# Altium schematic sheet Power System.SchDoc
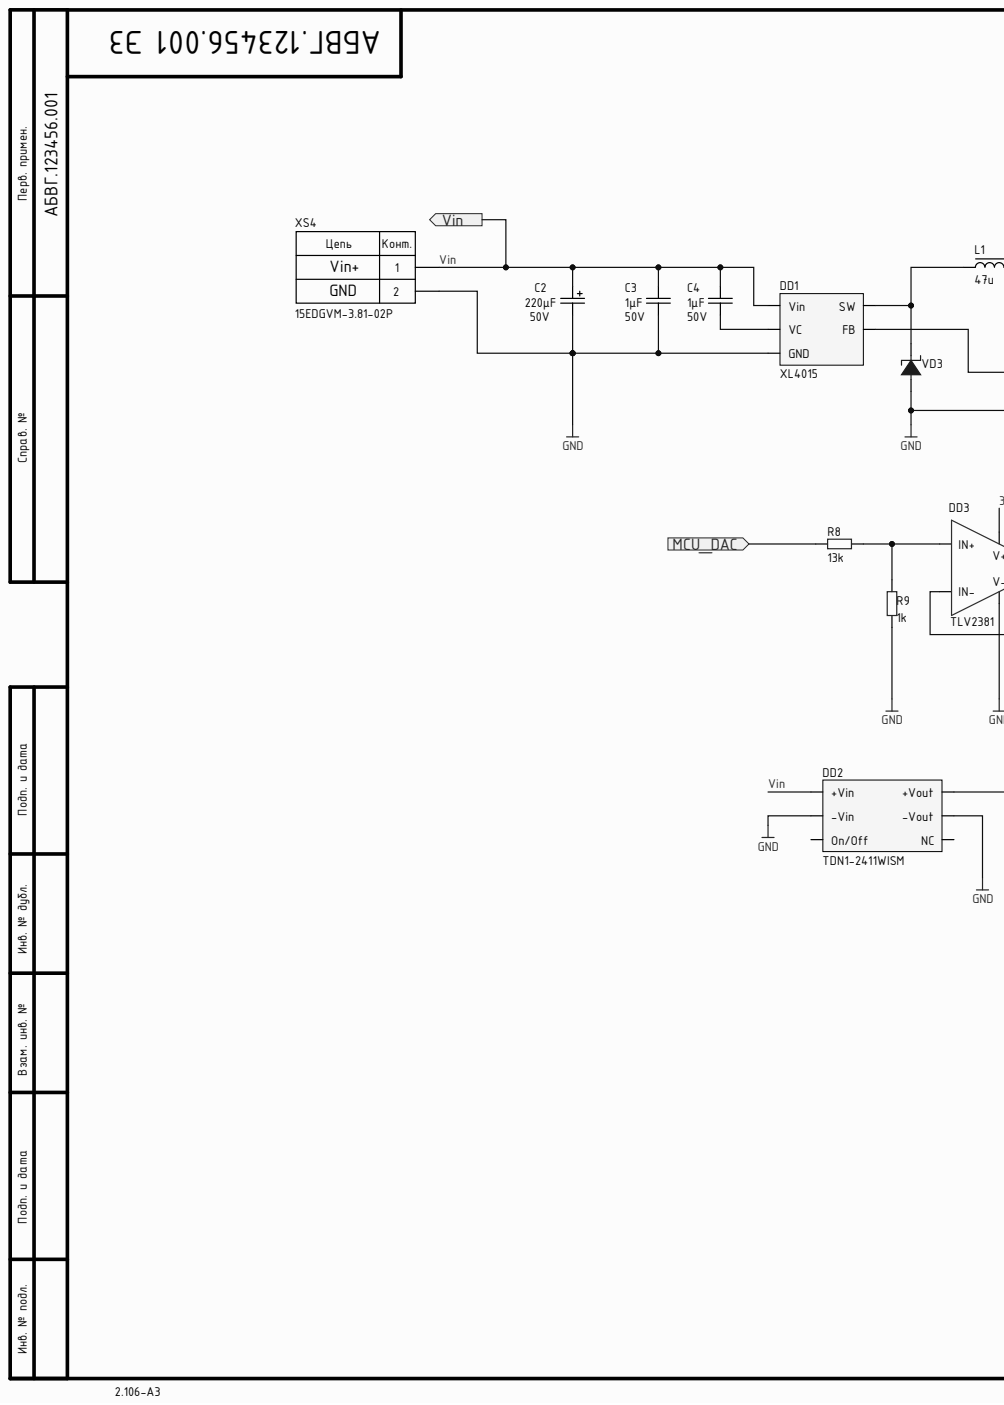
[diagram p0: Power System.SchDoc, left]
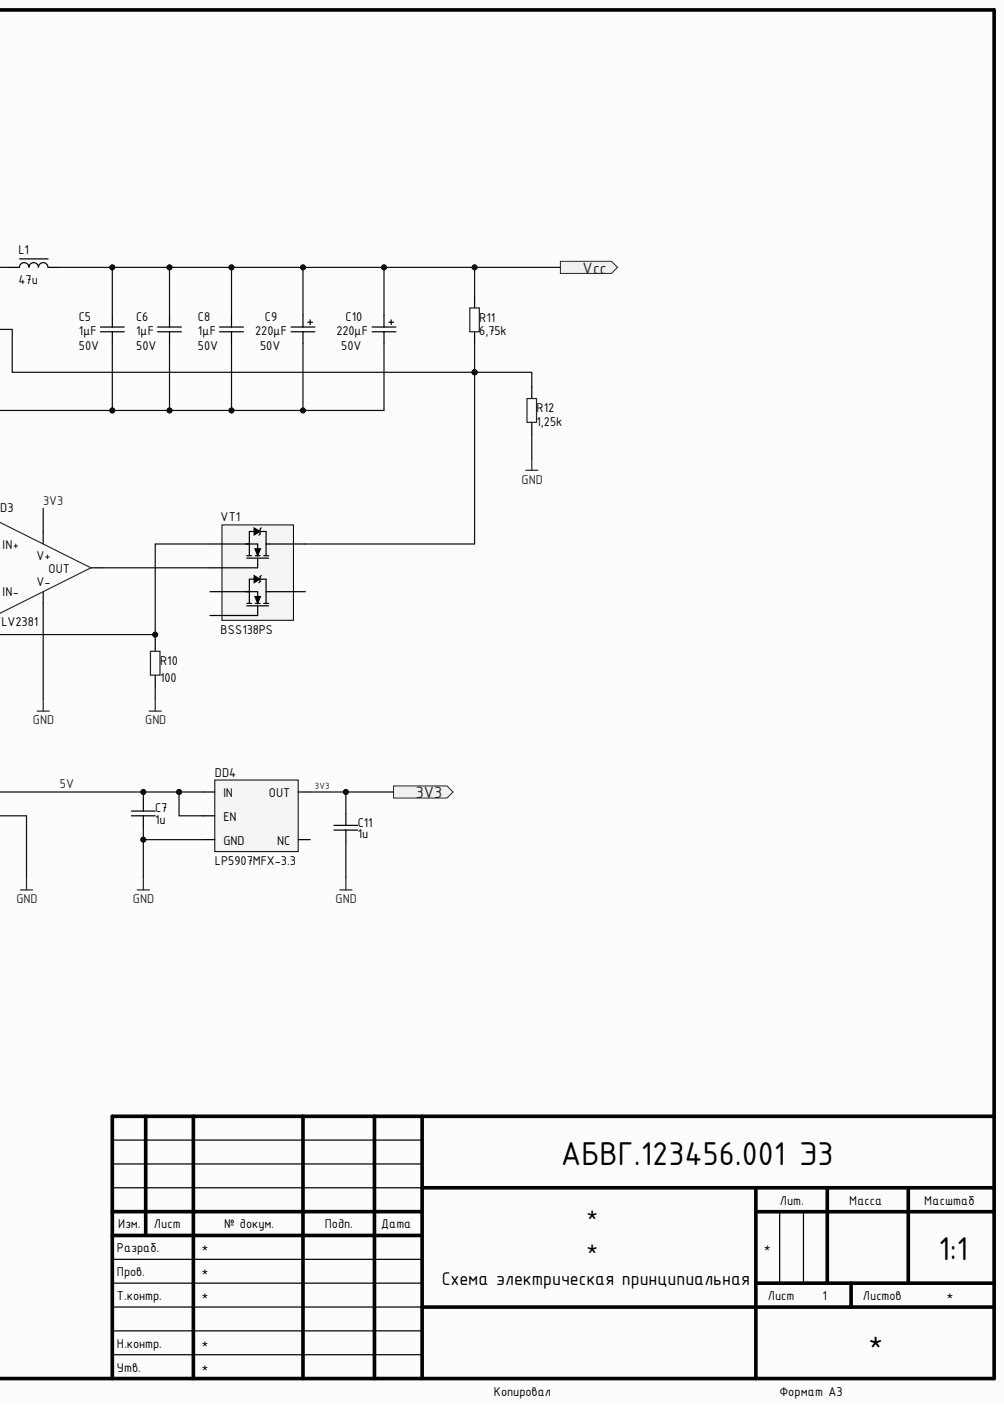
[diagram p1: Power System.SchDoc, right]

## Parts
C2  value 220μF  Voltage: 50V  [CAP: SMD-G Package(10x10)]
C3  value 1μF  Voltage: 50V  [CAP: 0805]  (hidden comment: Ceramic capacitor, 1μF, X7R, ±10%, 50V, 0805, -55...+125°C)
C4  value 1μF  Voltage: 50V  [CAP: 0805]  (hidden comment: Ceramic capacitor, 1μF, X7R, ±10%, 50V, 0805, -55...+125°C)
C5  value 1μF  Voltage: 50V  [CAP: 0805]  (hidden comment: Ceramic capacitor, 1μF, X7R, ±10%, 50V, 0805, -55...+125°C)
C6  value 1μF  Voltage: 50V  [CAP: 0805]  (hidden comment: Ceramic capacitor, 1μF, X7R, ±10%, 50V, 0805, -55...+125°C)
C7  value 1u  [CAP: 0603]  (hidden comment: Ceramic capacitor, 1u, X7R, ±10%, 16V, 0603, -55...+125°C)
C8  value 1μF  Voltage: 50V  [CAP: 0805]  (hidden comment: Ceramic capacitor, 1μF, X7R, ±10%, 50V, 0805, -55...+125°C)
C9  value 220μF  Voltage: 50V  [CAP: SMD-G Package(10x10)]
C10  value 220μF  Voltage: 50V  [CAP: SMD-G Package(10x10)]
C11  value 1u  [CAP: 0603]  (hidden comment: Ceramic capacitor, 1u, X7R, ±10%, 16V, 0603, -55...+125°C)
DD1  XL4015  [TO263-5L]
DD2  TDN1-2411WISM  [TDN1-2411WISM]
DD3  TLV2381  [SOT23-5]
DD4  LP5907MFX-3.3  [SOT23-5]
L1  47u  [IND: HM]
R8  value 13k  [RES: 0603]  (hidden comment: Resistor film, 13k, ±5%, 0603, 0.1W, -55...+125°C)
R9  value 1k  [RES: 0603]  (hidden comment: Resistor film, 1k, ±5%, 0603, 0.1W, -55...+125°C)
R10  value 100  [RES: 0603]  (hidden comment: Resistor film, 100, ±5%, 0603, 0.1W, -55...+125°C)
R11  value 6,75k  [RES: 0603]  (hidden comment: Resistor film, 6,75k, ±5%, 0603, 0.1W, -55...+125°C)
R12  value 1,25k  [RES: 0603]  (hidden comment: Resistor film, 1,25k, ±5%, 0603, 0.1W, -55...+125°C)
VD3  STPS1045DEE  [PowerFLAT(3.3x3.3)]  (value not drawn)
VT1  BSS138PS  [SOT363]
XS4  15EDGVM-3.81-02P  [CON: 15EDGVM-3.81-02P]

## Ports
3V3, MCU_DAC, Vcc, Vin

## Nets
GND: C2.2 C3.1 C5.1 C6.1 C7.1 C8.1 C9.2 C10.2 C11.1 DD1.1(GND) DD2.2(-Vin) DD2.6(-Vout) DD3.2(V-) DD4.2(GND) R9.2 R10.2 R12.1 VD3.1 XS4.2
3V3: C11.2 DD3.5(V+) DD4.5(OUT) ; port 3V3
5V: C7.2 DD2.7(+Vout) DD4.1(IN) DD4.3(EN)
MCU_DAC: R8.2 ; port MCU_DAC
Vcc: C5.2 C6.2 C8.2 C9.1 C10.1 L1.2 R11.2 ; port Vcc
Vin: C2.1 C3.2 C4.2 DD1.5(Vin) DD2.1(+Vin) XS4.1 ; port Vin
NetC4_1: C4.1 DD1.4(VC)
NetDD1_2: DD1.2(FB) R11.1 R12.2 VT1.6
NetDD1_3: DD1.3(SW) L1.1 VD3.2
NetDD3_1: DD3.1(OUT) VT1.2
NetDD3_3: DD3.3(IN+) R8.1 R9.1
NetDD3_4: DD3.4(IN-) R10.1 VT1.1

Not connected: DD2 4(On/Off) 5(NC); DD4 4(NC); VT1 3 4 5

## Library data of the parts
C2 C9 C10 = Aluminium: Component Kind: Standard; Library Name: capacitor.IntLib
C3-C6 C8 = Ceramic_0805: Manufacturer: Yageo; PartNumber: CC0805KRX7R7BB104; Component Kind: Standard; HelpURL: https://www.digikey.com/product-detail/en/yageo/CC0805KRX7R7BB104/311-1142-1-ND/303052; Library Name: capacitor.IntLib; Package: 0805; Temperature: -55...+125°C; Tolerance: ±10%; TypeCapacitor: Ceramic capacitor; TypeDielectric: X7R; ValueManufacturerPartNumber: Ceramic capacitor, 1μF, X7R, ±10%, 50V, 0805, -55...+125°C
C7 C11 = Ceramic_0603: Manufacturer: Yageo; PartNumber: CC0603KRX7R7BB104; Component Kind: Standard; HelpURL: https://www.digikey.com/product-detail/en/yageo/CC0603KRX7R7BB104/311-1088-1-ND/302998; Library Name: capacitor.IntLib; Package: 0603; Temperature: -55...+125°C; Tolerance: ±10%; TypeCapacitor: Ceramic capacitor; TypeDielectric: X7R; ValueManufacturerPartNumber: Ceramic capacitor, 1u, X7R, ±10%, 16V, 0603, -55...+125°C; Voltage: 16V
DD1 = XL4015: Buck DC-DC; Component Kind: Standard; ComponentLink1Description: Datasheet; ComponentLink1URL: http://www.xlsemi.com/datasheet/xl4015%20datasheet.pdf; Library Name: ic-power-supply.IntLib
DD2 = TDN1-2411WISM: DC-DC converter; Component Kind: Standard; ComponentLink1Description: Datasheet; ComponentLink1URL: https://www.tracopower.com/int/ru/model/tdn-1-2411wism; Library Name: ic-power-supply.IntLib
DD3 = TLV2381: OPAmp; Component Kind: Standard; ComponentLink1Description: Datasheet; ComponentLink1URL: https://www.ti.com/lit/ds/symlink/tlv2382.pdf?ts=1631189776503&ref_url=https%253A%252F%252Fwww.google.com%252F; Library Name: ic-amplifier.IntLib
DD4 = LP5907MFX-3.3: LDO 250 mA; Component Kind: Standard; ComponentLink1Description: Datasheet; ComponentLink1URL: https://www.ti.com/lit/ds/symlink/lp5907.pdf?ts=1629438025263&ref_url=https%253A%252F%252Fwww.google.com%252F; Library Name: ic-power-supply.IntLib
L1 = Inductor(HM): Component Kind: Standard; Library Name: inductor.IntLib
R8-R12 = Resistor_0603: Manufacturer: Yageo; PartNumber: RC0603JR-07100RL; Component Kind: Standard; HelpURL: https://www.digikey.com/product-detail/en/yageo/RC0603JR-07100RL/311-100GRCT-ND/729644; Library Name: resistor.IntLib; Package: 0603; Power: 0.1W; Temperature: -55...+125°C; Tolerance: ±5%; TypeResistor: Resistor film
  R8: ValueManufacturerPartNumber: Resistor film, 13k, ±5%, 0603, 0.1W, -55...+125°C
  R9: ValueManufacturerPartNumber: Resistor film, 1k, ±5%, 0603, 0.1W, -55...+125°C
  R10: ValueManufacturerPartNumber: Resistor film, 100, ±5%, 0603, 0.1W, -55...+125°C
  R11: ValueManufacturerPartNumber: Resistor film, 6,75k, ±5%, 0603, 0.1W, -55...+125°C
  R12: ValueManufacturerPartNumber: Resistor film, 1,25k, ±5%, 0603, 0.1W, -55...+125°C
VD3 = STPS1045DEE: 45V,10A Schottky; Component Kind: Standard; ComponentLink1Description: Datasheet; ComponentLink1URL: https://www.st.com/en/diodes-and-rectifiers/stps1045dee.html; Library Name: diode.IntLib
VT1 = BSS138PS: Component Kind: Standard; ComponentLink1Description: Datasheet; ComponentLink1URL: https://assets.nexperia.com/documents/data-sheet/BSS138PS.pdf; Library Name: transistor.IntLib
XS4 = 15EDGVM-3.81-02P: Component Kind: Standard; ComponentLink1Description: Datasheet; ComponentLink1URL: http://files.xn--e1agecchai.xn--p1ai/pdf/15edgvm-3.81.pdf; Library Name: connector.IntLib
